# Altium schematic sheet FPGA.SCHDOC(FPGA)
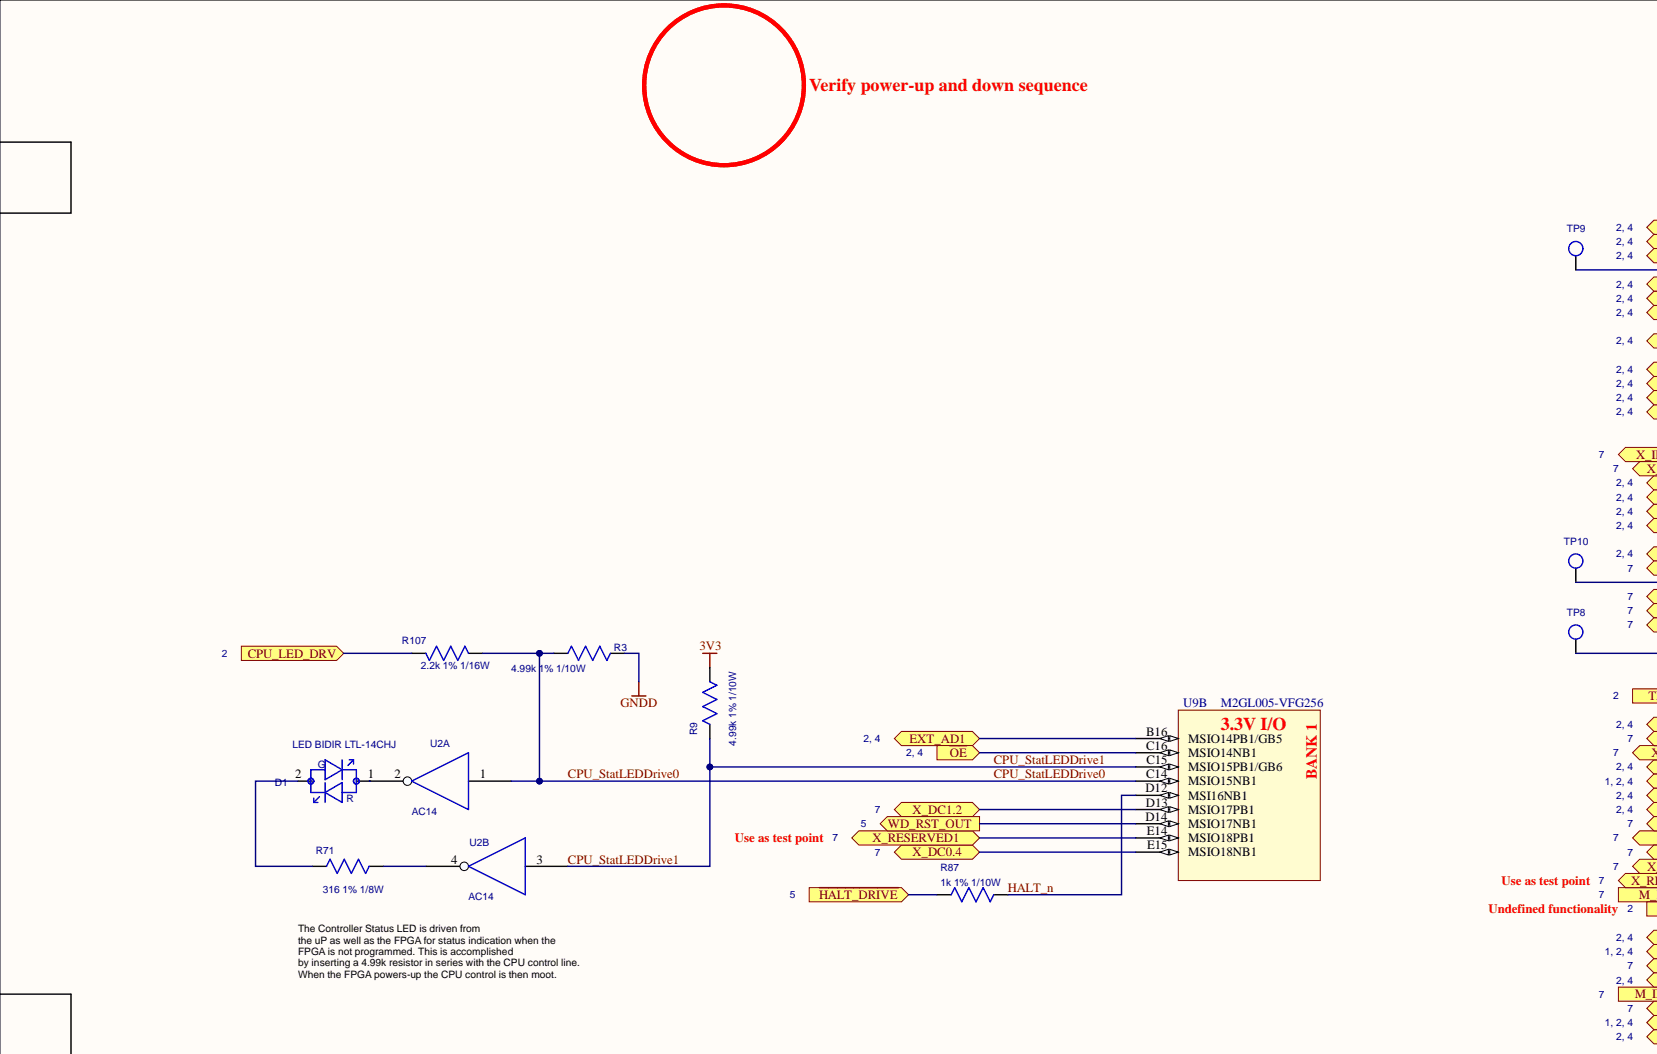
[diagram p0: FPGA.SCHDOC, top left]
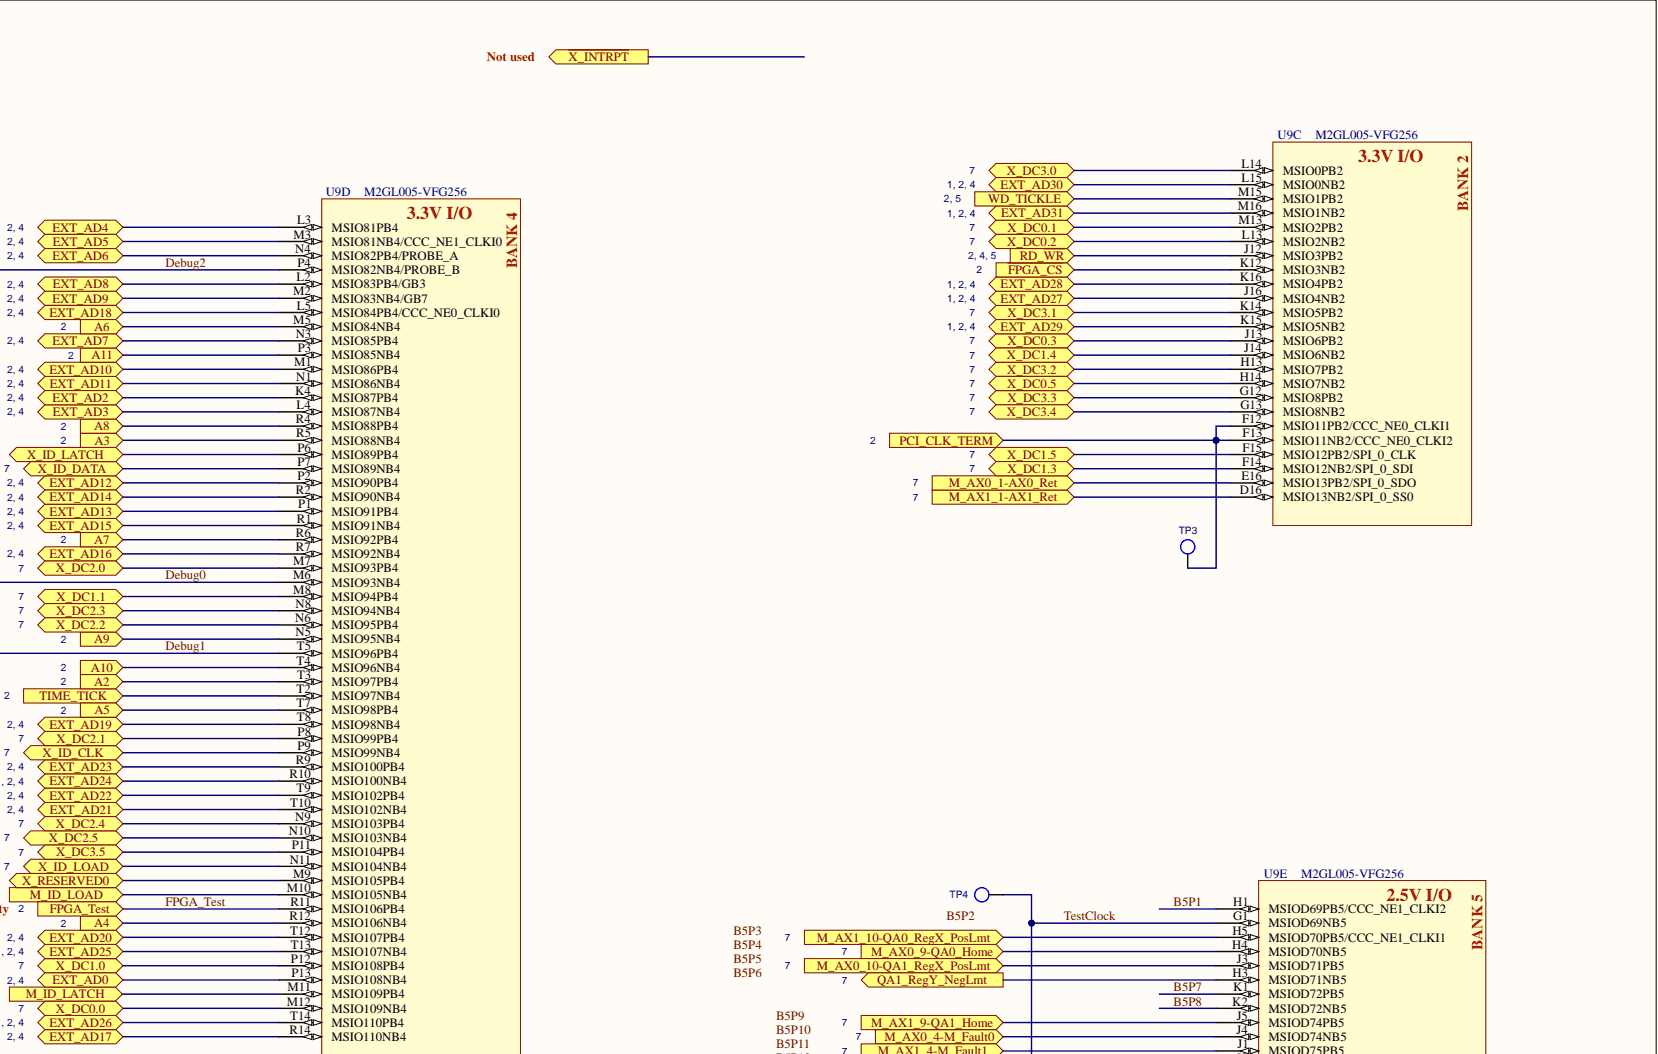
[diagram p1: FPGA.SCHDOC, top right]
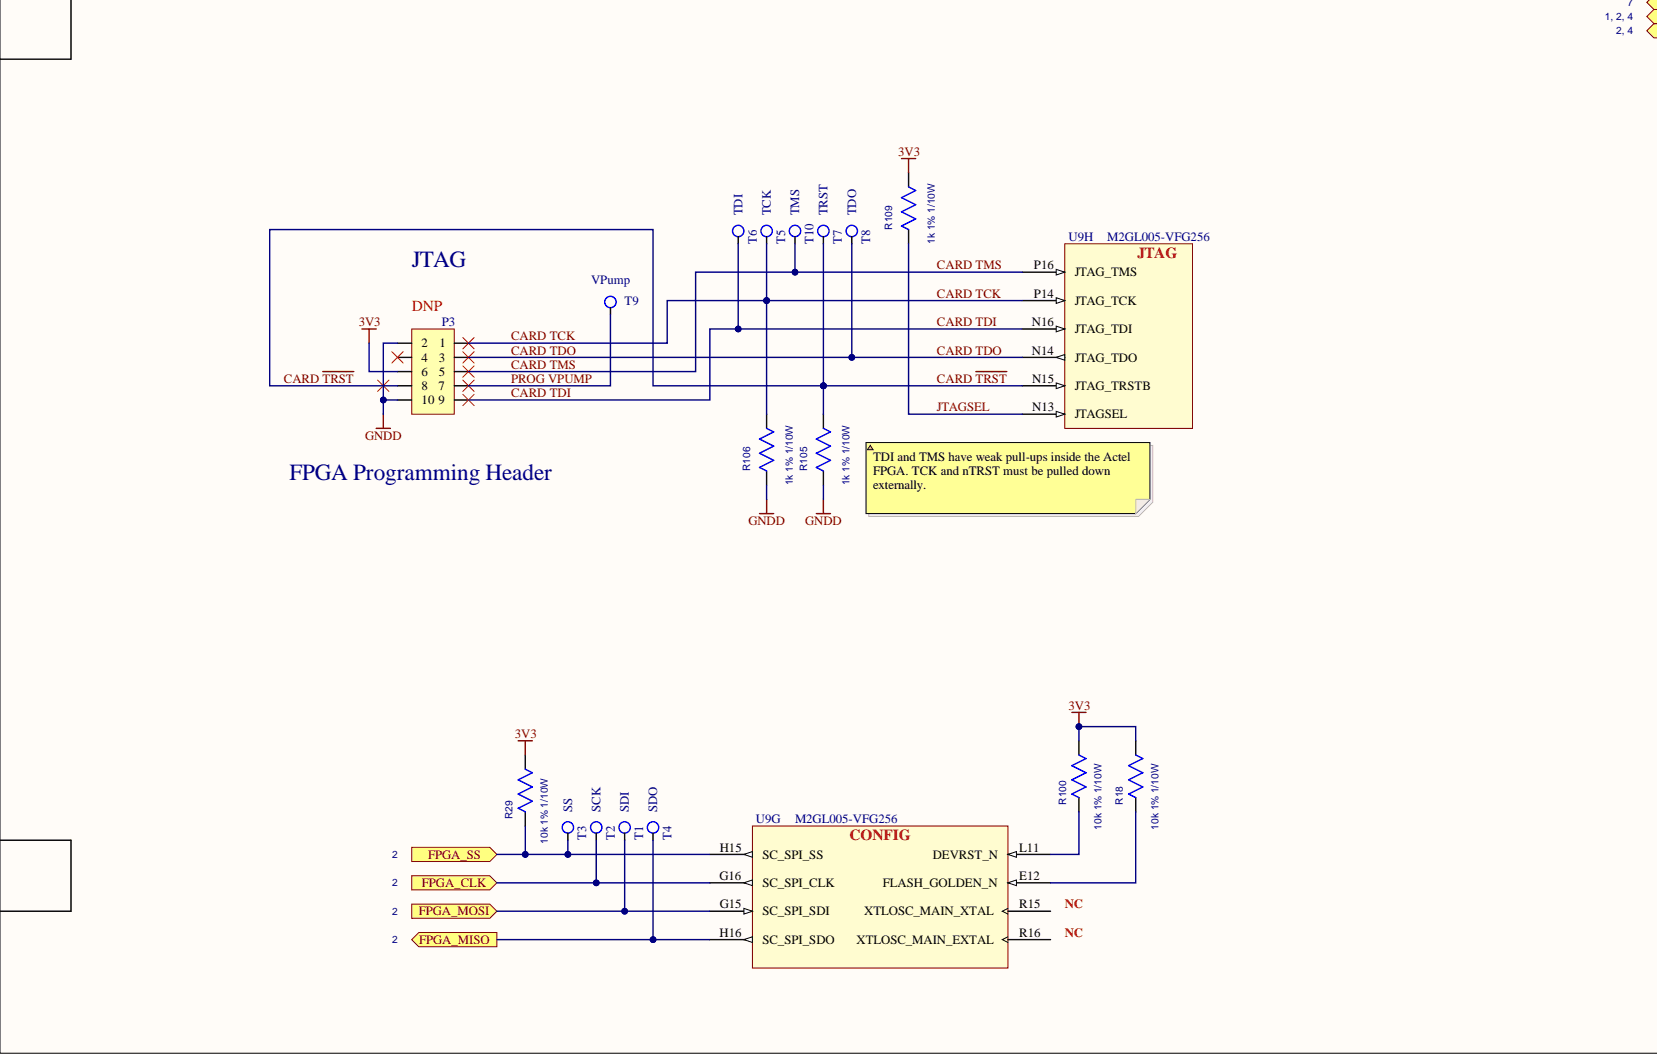
[diagram p2: FPGA.SCHDOC, bottom left]
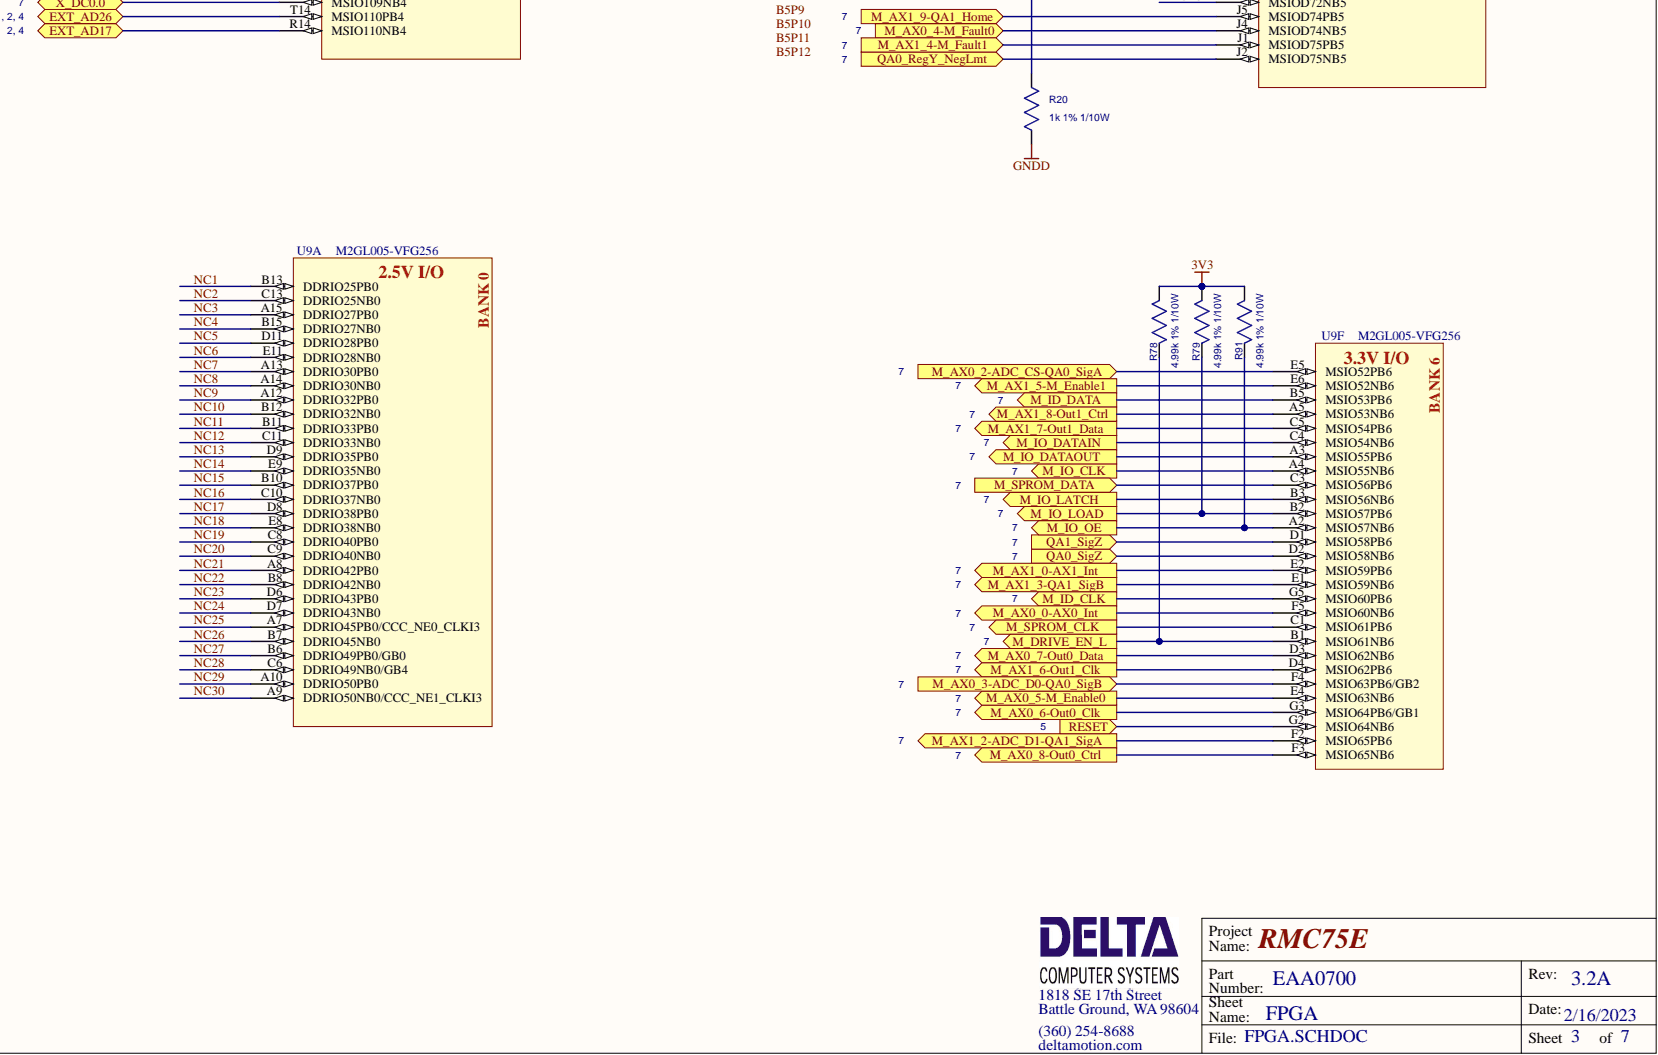
[diagram p3: FPGA.SCHDOC, bottom right]

## Parts
D1  LED BIDIR LTL-14CHJ  [LED 0.1 VERT]
P3  (no value)  [TC2050-IDC-NL]  (no BOM)
R3  4.99k 1% 1/10W  [CC0603]
R9  4.99k 1% 1/10W  [CC0603]
R18  10k 1% 1/10W  [CC0603]
R20  1k 1% 1/10W  [CC0603]
R29  10k 1% 1/10W  [CC0603]
R71  316 1% 1/8W  [CC0805]
R78  4.99k 1% 1/10W  [CC0603]
R79  4.99k 1% 1/10W  [CC0603]
R87  1k 1% 1/10W  [CC0603]
R91  4.99k 1% 1/10W  [CC0603]
R100  10k 1% 1/10W  [CC0603]
R105  1k 1% 1/10W  [CC0603]
R106  1k 1% 1/10W  [CC0603]
R107  2.2k 1% 1/16W  [CC0603]
R109  1k 1% 1/10W  [CC0603]
T1  SDI  [TP_TH20_ROUND40_BOTH]  (no BOM)
T2  SCK  [TP_TH20_ROUND40_BOTH]  (no BOM)
T3  SS  [TP_TH20_ROUND40_BOTH]  (no BOM)
T4  SDO  [TP_TH20_ROUND40_BOTH]  (no BOM)
T5  TCK  [TP_TH20_ROUND40_BOTH]  (no BOM)
T6  TDI  [TP_TH20_ROUND40_BOTH]  (no BOM)
T7  TRST  [TP_TH20_ROUND40_BOTH]  (no BOM)
T8  TDO  [TP_TH20_ROUND40_BOTH]  (no BOM)
T9  VPump  [TP_TH20_ROUND40_BOTH]  (no BOM)
T10  TMS  [TP_TH20_ROUND40_BOTH]  (no BOM)
TP3  TP_RND_SMT  [TP_SMT_RND]  (value not drawn)  (no BOM)
TP4  TP_RND_SMT  [TP_SMT_RND]  (value not drawn)  (no BOM)
TP8  D1  [TP_SMT_RND]  (value not drawn)  (no BOM)
TP9  D2  [TP_SMT_RND]  (value not drawn)  (no BOM)
TP10  D0  [TP_SMT_RND]  (value not drawn)  (no BOM)
U2  value AC14  [SO14_ACT04-SO14()]  (hidden comment: ACT04-SO14)  (parts A,B)
U9  M2GL005-VFG256  [BGA256C80P16X16_1410X1410X156]  (parts A,B,C,D,E,F,G,H)

## Ports
A2, A3, A4, A5, A6, A7, A8, A9, A10, A11, CPU_LED_DRV, EXT_AD0, EXT_AD1, EXT_AD2, EXT_AD3, EXT_AD4, EXT_AD5, EXT_AD6, EXT_AD7, EXT_AD8, EXT_AD9, EXT_AD10, EXT_AD11, EXT_AD12, EXT_AD13, EXT_AD14, EXT_AD15, EXT_AD16, EXT_AD17, EXT_AD18, EXT_AD19, EXT_AD20, EXT_AD21, EXT_AD22, EXT_AD23, EXT_AD24, EXT_AD25, EXT_AD26, EXT_AD27, EXT_AD28, EXT_AD29, EXT_AD30, EXT_AD31, FPGA_CLK, FPGA_MISO, FPGA_MOSI, FPGA_SS, FPGA_Test, M_AX0_0-AX0_Int, M_AX0_1-AX0_Ret, M_AX0_2-ADC_CS-QA0_SigA, M_AX0_3-ADC_D0-QA0_SigB, M_AX0_4-M_Fault0, M_AX0_5-M_Enable0, M_AX0_6-Out0_Clk, M_AX0_7-Out0_Data, M_AX0_8-Out0_Ctrl, M_AX0_9-QA0_Home, M_AX0_10-QA1_RegX_PosLmt, M_AX1_0-AX1_Int, M_AX1_1-AX1_Ret, M_AX1_2-ADC_D1-QA1_SigA, M_AX1_3-QA1_SigB, M_AX1_4-M_Fault1, M_AX1_5-M_Enable1, M_AX1_6-Out1_Clk, M_AX1_7-Out1_Data, M_AX1_8-Out1_Ctrl, M_AX1_9-QA1_Home, M_AX1_10-QA0_RegX_PosLmt, M_DRIVE_EN_L, M_ID_CLK, M_ID_DATA, M_ID_LATCH, M_ID_LOAD, M_IO_CLK, M_IO_DATAIN, M_IO_DATAOUT, M_IO_LATCH, M_IO_LOAD, M_IO_OE, M_SPROM_CLK, M_SPROM_DATA, PCI_CLK_TERM, QA0_RegY_NegLmt, QA0_SigZ, QA1_RegY_NegLmt, QA1_SigZ, RD_~{WR}, RESET, TIME_TICK, WD_RST_OUT, WD_TICKLE, X_DC0.0, X_DC0.1, X_DC0.2, X_DC0.3, X_DC0.4, X_DC0.5, X_DC1.0, X_DC1.1, X_DC1.2, X_DC1.3, X_DC1.4, X_DC1.5, X_DC2.0, X_DC2.1, X_DC2.2, X_DC2.3, X_DC2.4, X_DC2.5, X_DC3.0, X_DC3.1, X_DC3.2, X_DC3.3, X_DC3.4, X_DC3.5, X_ID_CLK, X_ID_DATA, X_ID_LATCH, X_ID_LOAD, X_RESERVED0, X_RESERVED1, ~{FPGA_CS}, ~{HALT_DRIVE}, ~{OE}, ~{X_INTRPT}

## Nets
3V3: P3.6 R9.2 R18.2 R29.2 R78.1 R79.1 R91.1 R100.2 R109.2
5V: U2.14
GNDD: P3.2 P3.10 R3.2 R20.1 R105.1 R106.1 U2.7
A2: U9.T3(MSIO97PB4) ; port A2
A3: U9.R5(MSIO88NB4) ; port A3
A4: U9.R12(MSIO106NB4) ; port A4
A5: U9.T7(MSIO98PB4) ; port A5
A6: U9.M5(MSIO84NB4) ; port A6
A7: U9.R6(MSIO92PB4) ; port A7
A8: U9.R4(MSIO88PB4) ; port A8
A9: U9.N5(MSIO95NB4) ; port A9
A10: U9.T4(MSIO96NB4) ; port A10
A11: U9.P3(MSIO85NB4) ; port A11
B5P1: U9.H1(MSIOD69PB5/CCC_NE1_CLKI2)
B5P7: U9.K1(MSIOD72PB5)
B5P8: U9.K2(MSIOD72NB5)
CARD ~{TRST}: P3.8 R105.2 T7.1 U9.N15(JTAG_TRSTB)
CARD TCK: P3.1 R106.2 T5.1 U9.P14(JTAG_TCK)
CARD TDI: P3.9 T6.1 U9.N16(JTAG_TDI)
CARD TDO: P3.3 T8.1 U9.N14(JTAG_TDO)
CARD TMS: P3.5 T10.1 U9.P16(JTAG_TMS)
CPU_LED_DRV: R107.1 ; port CPU_LED_DRV
CPU_StatLEDDrive0: R3.1 R107.2 U2.1 U9.C14(MSIO15NB1)
CPU_StatLEDDrive1: R9.1 U2.3 U9.C15(MSIO15PB1/GB6)
Debug0: TP10.1 U9.M6(MSIO93NB4)
Debug1: TP8.1 U9.T5(MSIO96PB4)
Debug2: TP9.1 U9.P4(MSIO82NB4/PROBE_B)
EXT_AD0: U9.P13(MSIO108NB4) ; port EXT_AD0
EXT_AD1: U9.B16(MSIO14PB1/GB5) ; port EXT_AD1
EXT_AD2: U9.K4(MSIO87PB4) ; port EXT_AD2
EXT_AD3: U9.L4(MSIO87NB4) ; port EXT_AD3
EXT_AD4: U9.L3(MSIO81PB4) ; port EXT_AD4
EXT_AD5: U9.M3(MSIO81NB4/CCC_NE1_CLKI0) ; port EXT_AD5
EXT_AD6: U9.N4(MSIO82PB4/PROBE_A) ; port EXT_AD6
EXT_AD7: U9.N3(MSIO85PB4) ; port EXT_AD7
EXT_AD8: U9.L2(MSIO83PB4/GB3) ; port EXT_AD8
EXT_AD9: U9.M2(MSIO83NB4/GB7) ; port EXT_AD9
EXT_AD10: U9.M1(MSIO86PB4) ; port EXT_AD10
EXT_AD11: U9.N1(MSIO86NB4) ; port EXT_AD11
EXT_AD12: U9.P2(MSIO90PB4) ; port EXT_AD12
EXT_AD13: U9.P1(MSIO91PB4) ; port EXT_AD13
EXT_AD14: U9.R2(MSIO90NB4) ; port EXT_AD14
EXT_AD15: U9.R1(MSIO91NB4) ; port EXT_AD15
EXT_AD16: U9.R7(MSIO92NB4) ; port EXT_AD16
EXT_AD17: U9.R14(MSIO110NB4) ; port EXT_AD17
EXT_AD18: U9.L5(MSIO84PB4/CCC_NE0_CLKI0) ; port EXT_AD18
EXT_AD19: U9.T8(MSIO98NB4) ; port EXT_AD19
EXT_AD20: U9.T12(MSIO107PB4) ; port EXT_AD20
EXT_AD21: U9.T10(MSIO102NB4) ; port EXT_AD21
EXT_AD22: U9.T9(MSIO102PB4) ; port EXT_AD22
EXT_AD23: U9.R9(MSIO100PB4) ; port EXT_AD23
EXT_AD24: U9.R10(MSIO100NB4) ; port EXT_AD24
EXT_AD25: U9.T13(MSIO107NB4) ; port EXT_AD25
EXT_AD26: U9.T14(MSIO110PB4) ; port EXT_AD26
EXT_AD27: U9.J16(MSIO4NB2) ; port EXT_AD27
EXT_AD28: U9.K16(MSIO4PB2) ; port EXT_AD28
EXT_AD29: U9.K15(MSIO5NB2) ; port EXT_AD29
EXT_AD30: U9.L15(MSIO0NB2) ; port EXT_AD30
EXT_AD31: U9.M16(MSIO1NB2) ; port EXT_AD31
~{FPGA_CS}: U9.K12(MSIO3NB2) ; port ~{FPGA_CS}
FPGA_CLK: T2.1 U9.G16(SC_SPI_CLK) ; port FPGA_CLK
FPGA_MISO: T4.1 U9.H16(SC_SPI_SDO) ; port FPGA_MISO
FPGA_MOSI: T1.1 U9.G15(SC_SPI_SDI) ; port FPGA_MOSI
FPGA_SS: R29.1 T3.1 U9.H15(SC_SPI_SS) ; port FPGA_SS
FPGA_Test: U9.R11(MSIO106PB4) ; port FPGA_Test
~{HALT_DRIVE}: R87.1 ; port ~{HALT_DRIVE}
HALT_n: R87.2 U9.D12(MSI16NB1)
JTAGSEL: R109.1 U9.N13(JTAGSEL)
M_AX0_0-AX0_Int: U9.F5(MSIO60NB6) ; port M_AX0_0-AX0_Int
M_AX0_1-AX0_Ret: U9.E16(MSIO13PB2/SPI_0_SDO) ; port M_AX0_1-AX0_Ret
M_AX0_2-ADC_CS-QA0_SigA: U9.E5(MSIO52PB6) ; port M_AX0_2-ADC_CS-QA0_SigA
M_AX0_3-ADC_D0-QA0_SigB: U9.F4(MSIO63PB6/GB2) ; port M_AX0_3-ADC_D0-QA0_SigB
M_AX0_4-M_Fault0: U9.J4(MSIOD74NB5) ; port M_AX0_4-M_Fault0
M_AX0_5-M_Enable0: U9.E4(MSIO63NB6) ; port M_AX0_5-M_Enable0
M_AX0_6-Out0_Clk: U9.G3(MSIO64PB6/GB1) ; port M_AX0_6-Out0_Clk
M_AX0_7-Out0_Data: U9.D3(MSIO62NB6) ; port M_AX0_7-Out0_Data
M_AX0_8-Out0_Ctrl: U9.F3(MSIO65NB6) ; port M_AX0_8-Out0_Ctrl
M_AX0_9-QA0_Home: U9.H4(MSIOD70NB5) ; port M_AX0_9-QA0_Home
M_AX0_10-QA1_RegX_PosLmt: U9.J3(MSIOD71PB5) ; port M_AX0_10-QA1_RegX_PosLmt
M_AX1_0-AX1_Int: U9.E2(MSIO59PB6) ; port M_AX1_0-AX1_Int
M_AX1_1-AX1_Ret: U9.D16(MSIO13NB2/SPI_0_SS0) ; port M_AX1_1-AX1_Ret
M_AX1_2-ADC_D1-QA1_SigA: U9.F2(MSIO65PB6) ; port M_AX1_2-ADC_D1-QA1_SigA
M_AX1_3-QA1_SigB: U9.E1(MSIO59NB6) ; port M_AX1_3-QA1_SigB
M_AX1_4-M_Fault1: U9.J1(MSIOD75PB5) ; port M_AX1_4-M_Fault1
M_AX1_5-M_Enable1: U9.E6(MSIO52NB6) ; port M_AX1_5-M_Enable1
M_AX1_6-Out1_Clk: U9.D4(MSIO62PB6) ; port M_AX1_6-Out1_Clk
M_AX1_7-Out1_Data: U9.C5(MSIO54PB6) ; port M_AX1_7-Out1_Data
M_AX1_8-Out1_Ctrl: U9.A5(MSIO53NB6) ; port M_AX1_8-Out1_Ctrl
M_AX1_9-QA1_Home: U9.J5(MSIOD74PB5) ; port M_AX1_9-QA1_Home
M_AX1_10-QA0_RegX_PosLmt: U9.H5(MSIOD70PB5/CCC_NE1_CLKI1) ; port M_AX1_10-QA0_RegX_PosLmt
M_DRIVE_EN_L: R78.2 U9.B1(MSIO61NB6) ; port M_DRIVE_EN_L
M_ID_CLK: U9.G5(MSIO60PB6) ; port M_ID_CLK
M_ID_DATA: U9.B5(MSIO53PB6) ; port M_ID_DATA
M_ID_LATCH: U9.M11(MSIO109PB4) ; port M_ID_LATCH
M_ID_LOAD: U9.M10(MSIO105NB4) ; port M_ID_LOAD
M_IO_CLK: U9.A4(MSIO55NB6) ; port M_IO_CLK
M_IO_DATAIN: U9.C4(MSIO54NB6) ; port M_IO_DATAIN
M_IO_DATAOUT: U9.A3(MSIO55PB6) ; port M_IO_DATAOUT
M_IO_LATCH: U9.B3(MSIO56NB6) ; port M_IO_LATCH
M_IO_LOAD: R79.2 U9.B2(MSIO57PB6) ; port M_IO_LOAD
M_IO_OE: R91.2 U9.A2(MSIO57NB6) ; port M_IO_OE
M_SPROM_CLK: U9.C1(MSIO61PB6) ; port M_SPROM_CLK
M_SPROM_DATA: U9.C3(MSIO56PB6) ; port M_SPROM_DATA
NC1: U9.B13(DDRIO25PB0)
NC2: U9.C13(DDRIO25NB0)
NC3: U9.A15(DDRIO27PB0)
NC4: U9.B15(DDRIO27NB0)
NC5: U9.D11(DDRIO28PB0)
NC6: U9.E11(DDRIO28NB0)
NC7: U9.A13(DDRIO30PB0)
NC8: U9.A14(DDRIO30NB0)
NC9: U9.A12(DDRIO32PB0)
NC10: U9.B12(DDRIO32NB0)
NC11: U9.B11(DDRIO33PB0)
NC12: U9.C11(DDRIO33NB0)
NC13: U9.D9(DDRIO35PB0)
NC14: U9.E9(DDRIO35NB0)
NC15: U9.B10(DDRIO37PB0)
NC16: U9.C10(DDRIO37NB0)
NC17: U9.D8(DDRIO38PB0)
NC18: U9.E8(DDRIO38NB0)
NC19: U9.C8(DDRIO40PB0)
NC20: U9.C9(DDRIO40NB0)
NC21: U9.A8(DDRIO42PB0)
NC22: U9.B8(DDRIO42NB0)
NC23: U9.D6(DDRIO43PB0)
NC24: U9.D7(DDRIO43NB0)
NC25: U9.A7(DDRIO45PB0/CCC_NE0_CLKI3)
NC26: U9.B7(DDRIO45NB0)
NC27: U9.B6(DDRIO49PB0/GB0)
NC28: U9.C6(DDRIO49NB0/GB4)
NC29: U9.A10(DDRIO50PB0)
NC30: U9.A9(DDRIO50NB0/CCC_NE1_CLKI3)
~{OE}: U9.C16(MSIO14NB1) ; port ~{OE}
PCI_CLK_TERM: TP3.1 U9.F12(MSIO11PB2/CCC_NE0_CLKI1) U9.F13(MSIO11NB2/CCC_NE0_CLKI2) ; port PCI_CLK_TERM
PROG VPUMP: P3.7 T9.1
QA0_RegY_NegLmt: U9.J2(MSIOD75NB5) ; port QA0_RegY_NegLmt
QA0_SigZ: U9.D2(MSIO58NB6) ; port QA0_SigZ
QA1_RegY_NegLmt: U9.H3(MSIOD71NB5) ; port QA1_RegY_NegLmt
QA1_SigZ: U9.D1(MSIO58PB6) ; port QA1_SigZ
RD_~{WR}: U9.J12(MSIO3PB2) ; port RD_~{WR}
RESET: U9.G2(MSIO64NB6) ; port RESET
TestClock: R20.2 TP4.1 U9.G1(MSIOD69NB5)
TIME_TICK: U9.T2(MSIO97NB4) ; port TIME_TICK
WD_RST_OUT: U9.D14(MSIO17NB1) ; port WD_RST_OUT
WD_TICKLE: U9.M15(MSIO1PB2) ; port WD_TICKLE
X_DC0.0: U9.M12(MSIO109NB4) ; port X_DC0.0
X_DC0.1: U9.M13(MSIO2PB2) ; port X_DC0.1
X_DC0.2: U9.L13(MSIO2NB2) ; port X_DC0.2
X_DC0.3: U9.J13(MSIO6PB2) ; port X_DC0.3
X_DC0.4: U9.E15(MSIO18NB1) ; port X_DC0.4
X_DC0.5: U9.H14(MSIO7NB2) ; port X_DC0.5
X_DC1.0: U9.P12(MSIO108PB4) ; port X_DC1.0
X_DC1.1: U9.M8(MSIO94PB4) ; port X_DC1.1
X_DC1.2: U9.D13(MSIO17PB1) ; port X_DC1.2
X_DC1.3: U9.F14(MSIO12NB2/SPI_0_SDI) ; port X_DC1.3
X_DC1.4: U9.J14(MSIO6NB2) ; port X_DC1.4
X_DC1.5: U9.F15(MSIO12PB2/SPI_0_CLK) ; port X_DC1.5
X_DC2.0: U9.M7(MSIO93PB4) ; port X_DC2.0
X_DC2.1: U9.P8(MSIO99PB4) ; port X_DC2.1
X_DC2.2: U9.N6(MSIO95PB4) ; port X_DC2.2
X_DC2.3: U9.N8(MSIO94NB4) ; port X_DC2.3
X_DC2.4: U9.N9(MSIO103PB4) ; port X_DC2.4
X_DC2.5: U9.N10(MSIO103NB4) ; port X_DC2.5
X_DC3.0: U9.L14(MSIO0PB2) ; port X_DC3.0
X_DC3.1: U9.K14(MSIO5PB2) ; port X_DC3.1
X_DC3.2: U9.H13(MSIO7PB2) ; port X_DC3.2
X_DC3.3: U9.G12(MSIO8PB2) ; port X_DC3.3
X_DC3.4: U9.G13(MSIO8NB2) ; port X_DC3.4
X_DC3.5: U9.P11(MSIO104PB4) ; port X_DC3.5
X_ID_CLK: U9.P9(MSIO99NB4) ; port X_ID_CLK
X_ID_DATA: U9.P7(MSIO89NB4) ; port X_ID_DATA
X_ID_LATCH: U9.P6(MSIO89PB4) ; port X_ID_LATCH
X_ID_LOAD: U9.N11(MSIO104NB4) ; port X_ID_LOAD
X_RESERVED0: U9.M9(MSIO105PB4) ; port X_RESERVED0
X_RESERVED1: U9.E14(MSIO18PB1) ; port X_RESERVED1
NetD1_1: D1.1 U2.2
NetD1_2: D1.2 R71.1
NetR18_1: R18.1 U9.E12(FLASH_GOLDEN_N)
NetR71_2: R71.2 U2.4
NetR100_1: R100.1 U9.L11(DEVRST_N)
B5P2:
B5P3:
B5P4:
B5P5:
B5P6:
B5P9:
B5P10:
B5P11:
B5P12:
~{X_INTRPT}: ; port ~{X_INTRPT}

Not connected: P3 4; U9 R15(XTLOSC_MAIN_XTAL) R16(XTLOSC_MAIN_EXTAL)

## Library data of the parts
D1 = LED BIDIR LTL-14CHJ: LED Green/Red Diff 3mm Round T1; Manufacturer: Lite On; Component Kind: Standard; PartNumber: LTL-14CHJ
P3 = TC2050-IDC: TagConnect, Plug-of-Nails 2-Row 10-Pin Header; ComponentType: Connector; DateUpdated: 01/19/2012; Library Name: Connector.IntLib; Manufacturer: Tag Connect; PartNumber: TC2050-IDC
R3 R9 R78 R79 R91 = RES_GEN_SMT0603: Res 4.99k 1% 1/10W 100ppm 0603; Manufacturer: Venkel; PartNumber: CR0603-10W-4991FT; Component Kind: Standard; Value: 4.99k 1% 1/10W
R18 R29 R100 = RES_GEN_SMT0603: Res 10k 1% 1/10W 100ppm 0603; Manufacturer: Venkel; PartNumber: CR0603-10W-1002FT; Component Kind: Standard; Value: 10k 1% 1/10W
R20 R87 R105 R106 R109 = RES_GEN_SMT0603: Res 1k 1% 1/10W 100ppm 0603; Manufacturer: Venkel; PartNumber: CR0603-10W-1001FT; Component Kind: Standard; Value: 1k 1% 1/10W
R71 = RES_GEN_SMT0805: Res 316 1% 1/8W 100ppm 0805; Manufacturer: Venkel; PartNumber: CR0805-8W-3160FT; Component Kind: Standard; Value: 316 1% 1/8W
R107 = RES_GEN_SMT0603: Res 2.2k 1% 1/10W 100ppm 0603; Manufacturer: Venkel; PartNumber: CR0603-10W-2201FT; Component Kind: Standard; Value: 2.2k 1% 1/16W
T1-T10 = Test Point: Generic Test Point; ComponentType: Connector; DateUpdated: 10/19/2010; Library Name: Connector.IntLib
TP8-TP10 = TP_RND_SMT: Description: TP_RND_SMT
U2 = ACT04-SO14: Hex Inverter Schmitt Trigger 6-Ch 6-Inp 14SOIC; Manufacturer: Fairchild; PartNumber: 74ACT14SCX; Component Kind: Standard
U9 = M2GL005-VFG265: Microchip Igloo2 FPGA 6060 LE 161 IO; Component Kind: Standard; ComponentType: ProgrammableLogic; DateUpdated: 04/19/2022; Manufacturer: Microchip; PartNumber: M2GL005-VFG256; Library Name: ProgrammableLogic.IntLib
TP3 TP4 = TP_RND_SMT: Description: TP_RND_SMT
